# Altium schematic sheet NVME.SchDoc
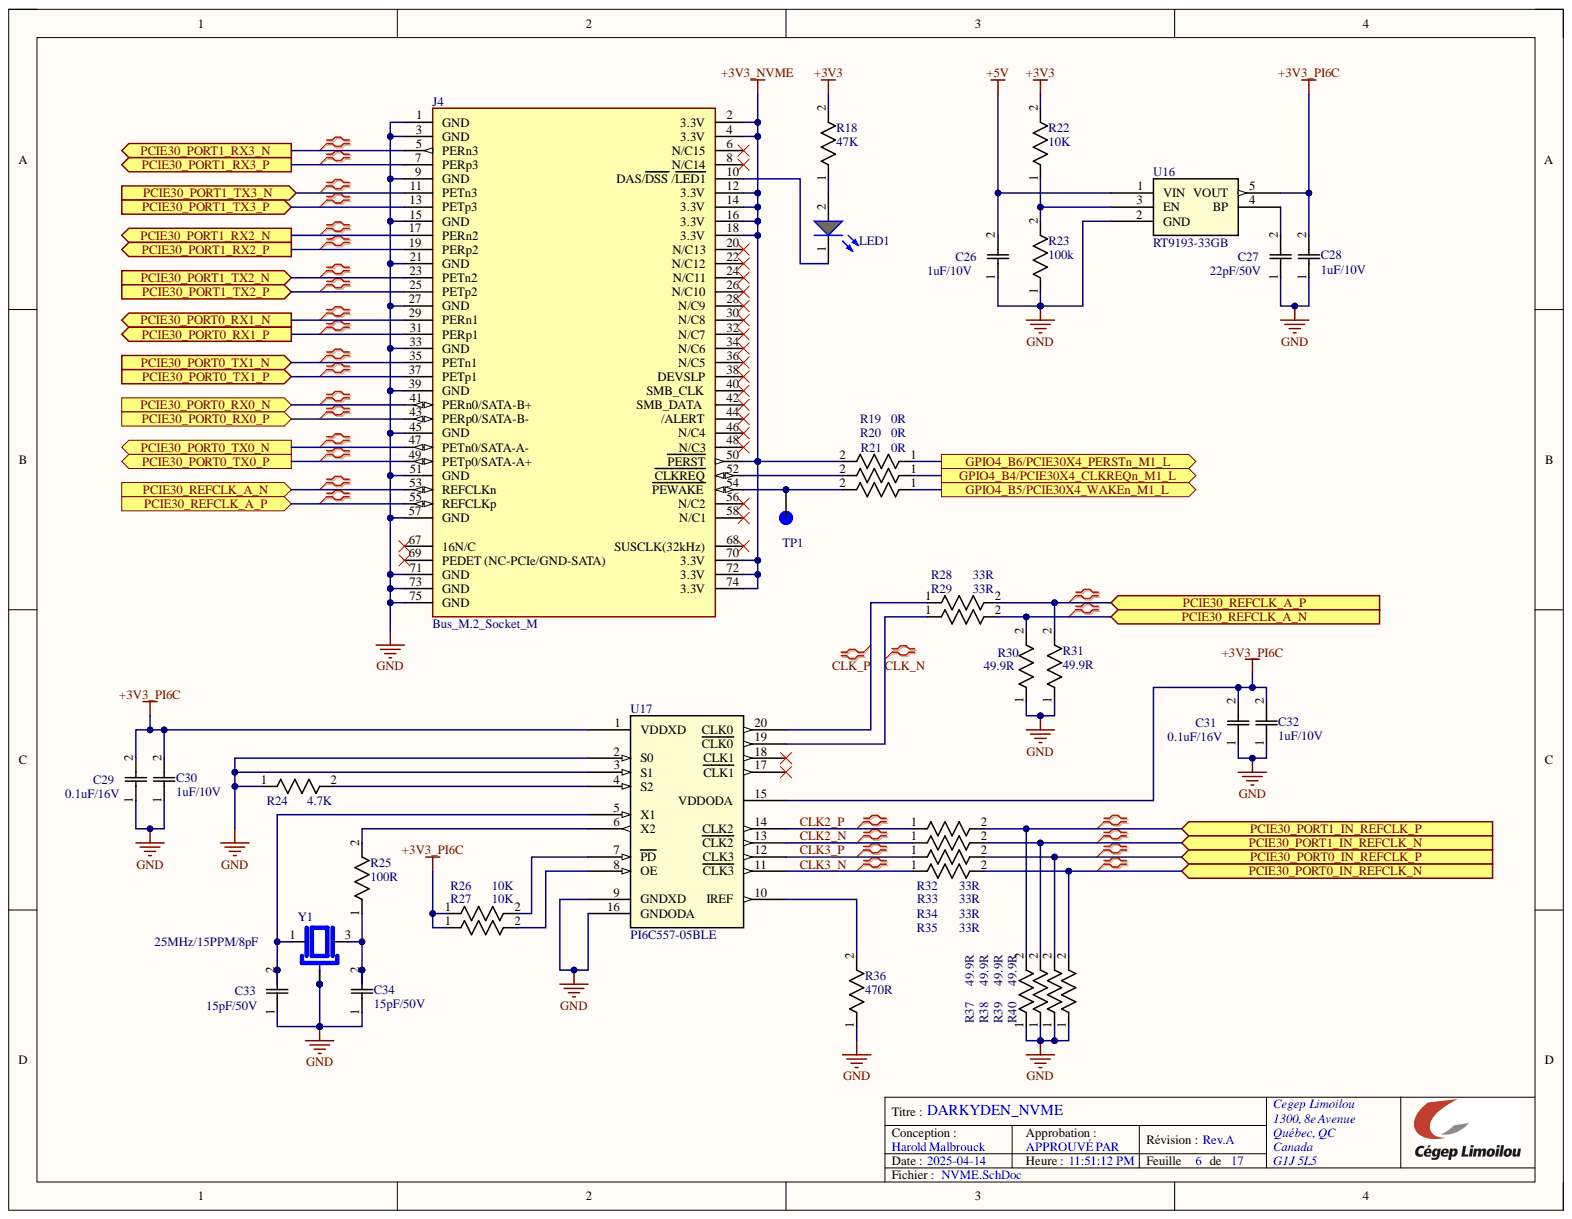
[diagram p0: NVME.SchDoc]

## Parts
C26  1uF/10V  [CAP_CC0805_YAG]
C27  22pF/50V  [CAP_CC0805_YAG]
C28  1uF/10V  [CAP_CC0805_YAG]
C29  0.1uF/16V  [CAP_CC0805_YAG]
C30  1uF/10V  [CAP_CC0805_YAG]
C31  0.1uF/16V  [CAP_CC0805_YAG]
C32  1uF/10V  [CAP_CC0805_YAG]
C33  15pF/50V  [CAP_CC0805_YAG]
C34  15pF/50V  [CAP_CC0805_YAG]
J4  Bus_M.2_Socket_M  [AMPHENOL_MDT420M03001]
LED1  Green, 3.2V, 140°  [WL-SMCD_0603]  (value not drawn)
R18  47K  [RES_0816_SUS]
R19  0R  [RES_0816_SUS]
R20  0R  [RES_0816_SUS]
R21  0R  [RES_0816_SUS]
R22  10K  [RES_0816_SUS]
R23  100k  [RES_0816_SUS]
R24  4.7K  [RES_0816_SUS]
R25  100R  [RES_0816_SUS]
R26  10K  [RES_0816_SUS]
R27  10K  [RES_0816_SUS]
R28  33R  [RES_0816_SUS]
R29  33R  [RES_0816_SUS]
R30  49.9R  [RES_0816_SUS]
R31  49.9R  [RES_0816_SUS]
R32  33R  [RES_0816_SUS]
R33  33R  [RES_0816_SUS]
R34  33R  [RES_0816_SUS]
R35  33R  [RES_0816_SUS]
R36  470R  [RES_0816_SUS]
R37  49.9R  [RES_0816_SUS]
R38  49.9R  [RES_0816_SUS]
R39  49.9R  [RES_0816_SUS]
R40  49.9R  [RES_0816_SUS]
R103  RG1608P-104-B-T5  [RES_0816_SUS]  (value not drawn)
TP1  5006  [KEYSTONE_5006]  (value not drawn)
U16  RT9193-33GB  [SOT-23-5_RIT]
U17  PI6C557-05BLE  [L20_PER-M]
Y1  25MHz/15PPM/8pF  [XTAL_ECS-250-8-37B2-RWY-TR]

## Ports
GPIO4_B4/PCIE30X4_CLKREQn_M1_L, GPIO4_B5/PCIE30X4_WAKEn_M1_L, GPIO4_B6/PCIE30X4_PERSTn_M1_L, PCIE30_PORT0_IN_REFCLK_N, PCIE30_PORT0_IN_REFCLK_P, PCIE30_PORT0_RX0_N, PCIE30_PORT0_RX0_P, PCIE30_PORT0_RX1_N, PCIE30_PORT0_RX1_P, PCIE30_PORT0_TX0_N, PCIE30_PORT0_TX0_P, PCIE30_PORT0_TX1_N, PCIE30_PORT0_TX1_P, PCIE30_PORT1_IN_REFCLK_N, PCIE30_PORT1_IN_REFCLK_P, PCIE30_PORT1_RX2_N, PCIE30_PORT1_RX2_P, PCIE30_PORT1_RX3_N, PCIE30_PORT1_RX3_P, PCIE30_PORT1_TX2_N, PCIE30_PORT1_TX2_P, PCIE30_PORT1_TX3_N, PCIE30_PORT1_TX3_P, PCIE30_REFCLK_A_N, PCIE30_REFCLK_A_P

## Nets
+3V3: R18.2 R22.2
+3V3_NVME: J4.2(3.3V) J4.4(3.3V) J4.12(3.3V) J4.14(3.3V) J4.16(3.3V) J4.18(3.3V) J4.50(PERST) J4.70(3.3V) J4.72(3.3V) J4.74(3.3V) R19.2
+3V3_PI6C: C28.2 C29.2 C30.2 C31.2 C32.2 R26.1 R27.1 U16.5(VOUT) U17.1(VDDXD) U17.15(VDDODA)
+5V: C26.2 U16.1(VIN)
GND: C26.1 C27.1 C28.1 C29.1 C30.1 C31.1 C32.1 C33.1 C34.1 J4.1(GND) J4.3(GND) J4.9(GND) J4.15(GND) J4.21(GND) J4.27(GND) J4.33(GND) J4.39(GND) J4.45(GND) J4.51(GND) J4.57(GND) J4.71(GND) J4.73(GND) J4.75(GND) R23.1 R24.1 R30.1 R31.1 R36.1 R37.1 R38.1 R39.1 R40.1 U16.2(GND) U17.2(S0) U17.3(S1) U17.9(GNDXD) U17.16(GNDODA) Y1.2 Y1.4
CLK2_N: R33.1 U17.13(CLK2)
CLK2_P: R32.1 U17.14(CLK2)
CLK3_N: R35.1 U17.11(CLK3)
CLK3_P: R34.1 U17.12(CLK3)
CLK_N: R29.1 U17.19(CLK0)
CLK_P: R28.1 U17.20(CLK0)
GPIO4_B4/PCIE30X4_CLKREQn_M1_L: R20.1 ; port GPIO4_B4/PCIE30X4_CLKREQn_M1_L
GPIO4_B5/PCIE30X4_WAKEn_M1_L: R21.1 ; port GPIO4_B5/PCIE30X4_WAKEn_M1_L
GPIO4_B6/PCIE30X4_PERSTn_M1_L: R19.1 ; port GPIO4_B6/PCIE30X4_PERSTn_M1_L
PCIE30_PORT0_IN_REFCLK_N: R35.2 R40.2 ; port PCIE30_PORT0_IN_REFCLK_N
PCIE30_PORT0_IN_REFCLK_P: R34.2 R39.2 ; port PCIE30_PORT0_IN_REFCLK_P
PCIE30_PORT0_RX0_N: J4.41(PERn0/SATA-B+) ; port PCIE30_PORT0_RX0_N
PCIE30_PORT0_RX0_P: J4.43(PERp0/SATA-B-) ; port PCIE30_PORT0_RX0_P
PCIE30_PORT0_RX1_N: J4.29(PERn1) ; port PCIE30_PORT0_RX1_N
PCIE30_PORT0_RX1_P: J4.31(PERp1) ; port PCIE30_PORT0_RX1_P
PCIE30_PORT0_TX0_N: J4.47(PETn0/SATA-A-) ; port PCIE30_PORT0_TX0_N
PCIE30_PORT0_TX0_P: J4.49(PETp0/SATA-A+) ; port PCIE30_PORT0_TX0_P
PCIE30_PORT0_TX1_N: J4.35(PETn1) ; port PCIE30_PORT0_TX1_N
PCIE30_PORT0_TX1_P: J4.37(PETp1) ; port PCIE30_PORT0_TX1_P
PCIE30_PORT1_IN_REFCLK_N: R33.2 R38.2 ; port PCIE30_PORT1_IN_REFCLK_N
PCIE30_PORT1_IN_REFCLK_P: R32.2 R37.2 ; port PCIE30_PORT1_IN_REFCLK_P
PCIE30_PORT1_RX2_N: J4.17(PERn2) ; port PCIE30_PORT1_RX2_N
PCIE30_PORT1_RX2_P: J4.19(PERp2) ; port PCIE30_PORT1_RX2_P
PCIE30_PORT1_RX3_N: J4.5(PERn3) ; port PCIE30_PORT1_RX3_N
PCIE30_PORT1_RX3_P: J4.7(PERp3) ; port PCIE30_PORT1_RX3_P
PCIE30_PORT1_TX2_N: J4.23(PETn2) ; port PCIE30_PORT1_TX2_N
PCIE30_PORT1_TX2_P: J4.25(PETp2) ; port PCIE30_PORT1_TX2_P
PCIE30_PORT1_TX3_N: J4.11(PETn3) ; port PCIE30_PORT1_TX3_N
PCIE30_PORT1_TX3_P: J4.13(PETp3) ; port PCIE30_PORT1_TX3_P
PCIE30_REFCLK_A_N: J4.53(REFCLKn) R29.2 R30.2 ; port PCIE30_REFCLK_A_N
PCIE30_REFCLK_A_P: J4.55(REFCLKp) R28.2 R31.2 ; port PCIE30_REFCLK_A_P
NetC27_2: C27.2 U16.4(BP)
NetC33_2: C33.2 U17.5(X1) Y1.1
NetC34_2: C34.2 R25.1 Y1.3
NetJ4_10: J4.10(DAS/DSS_/LED1) LED1.1
NetJ4_52: J4.52(CLKREQ) R20.2
NetJ4_54: J4.54(PEWAKE) R21.2 TP1.TP
NetLED1_2: LED1.2 R18.1
NetR22_1: R22.1 R23.2 U16.3(EN)
NetR24_2: R24.2 U17.4(S2)
NetR25_2: R25.2 U17.6(X2)
NetR26_2: R26.2 U17.7(PD)
NetR27_2: R27.2 U17.8(OE)
NetR36_2: R36.2 U17.10(IREF)

Not connected: J4 6(N/C15) 8(N/C14) 20(N/C13) 22(N/C12) 24(N/C11) 26(N/C10) 28(N/C9) 30(N/C8) 32(N/C7) 34(N/C6) 36(N/C5) 38(DEVSLP) 40(SMB_CLK) 42(SMB_DATA) 44(/ALERT) 46(N/C4) 48(N/C3) 56(N/C2) 58(N/C1) 67(16N/C) 68(SUSCLK(32kHz)) 69(PEDET_(NC-PCIe/GND-SATA)); R103 1 2; U17 17(CLK1) 18(CLK1)

## Library data of the parts
C26-C34 = Capacitor_0805: No Description Available; Mfr_Name: Yageo; Component Kind: Standard; Library Name: Capacitor_0805.SchLib
J4 = Bus_M.2_Socket_M: MF: Amphenol Commercial; MP: MDT420M03001; Availability: Unavailable; Component Kind: Standard; Library Name: Bus_M.2_Socket_M.SchLib; Package: None; Price: None
LED1 = GREEN: WL-SMCD Mono-color Chip LED Diffused, SMT, size 0603, Green, 3.2V, 140°; Manufacturer: Wurth Elektronik; Manufacturer Part Number: 150060GS55040; Case/Size Code: 0603; Chip Technology: InGaN; Dominant Wavelength: 525nm; Luminous Intensity: 430mcd; Peak Wavelength: 520nm; Category: Signal LEDs; Component Kind: Standard; ComponentLink1Description: Website Link; ComponentLink1URL: https://www.we-online.com/redexpert/article/150060GS55040?ad; ComponentLink2Description: Datasheet Link; ComponentLink2URL: https://www.we-online.com/redexpert/spec/150060GS55040?ad; Emitting Color: Green; Forward Voltage: 3.2V; Height: 0.4mm; Length: 1.6mm; Library Name: 0603_LED.SchLib; Match Code: WL-SMCD; Mount: Surface Mount; Operating Temperature Max: 85°C; Operating Temperature Min: -40°C; Packaging: Tape and Reel; Simulation: 0603_150060GS55040; Viewing Angle: 140°; Width: 0.8mm
R18-R40 R103 = RG1608P-104-B-T5: No Description Available; Mfr_Name: Susumu; Component Kind: Standard; Library Name: RES_SMD_0603.SchLib
TP1 = 5006: Test Point Compact THM PC .350 L .125 OD Heat Resistant Nylon 46 Black | Keystone Electronics 5006; MF: Keystone Electronics Corp.; Check_prices: https://www.snapeda.com/parts/5006/Keystone/view-part/?ref=eda; Component Kind: Standard; Library Name: test_point.SchLib; MP: 5006; Package: NON STANDARD-1 Keystone; SnapEDA_Link: https://www.snapeda.com/parts/5006/Keystone/view-part/?ref=snap
U16 = RT9193-33GB: No Description Available; Mfr_Name: Richtek Technology; Component Kind: Standard; Library Name: RT9193-33GB.SchLib; RefDes: RefDes; Type: Type
U17 = PI6C557-05BLE: No Description Available; Mfr_Name: Pericom; Component Kind: Standard; Library Name: PI6C557-05BLE.SchLib; RefDes: RefDes; Type: Type
Y1 = ECS-250-8-37B2-RWY-TR: MF: {'manufacturer': u'ECS Inc.'}; Availability: Unavailable; Component Kind: Standard; Library Name: ECS-250-8-37B2-RWY-TR.SchLib; MP: ECS-250-8-37B2-RWY-TR; Package: None; Price: None
